# Altium schematic sheet di.SchDoc(di)
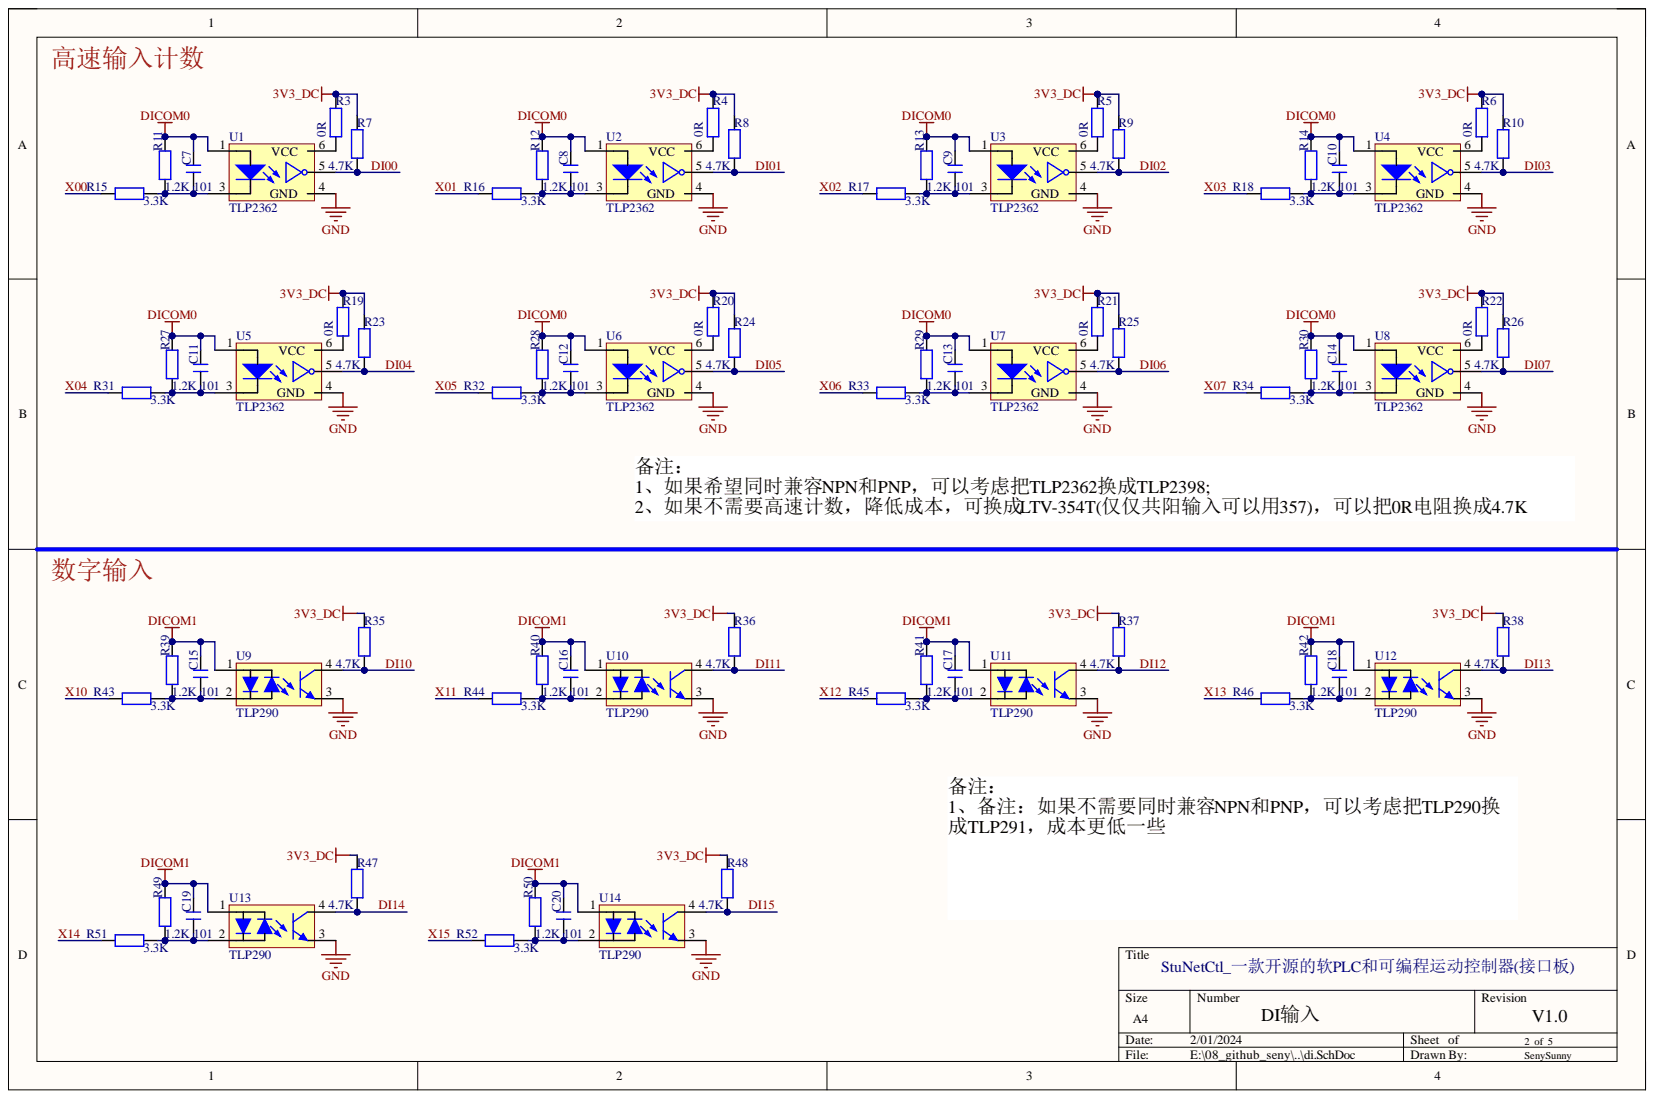
[diagram p0: di.SchDoc]

## Parts
C7  101  [C 0603]
C8  101  [C 0603]
C9  101  [C 0603]
C10  101  [C 0603]
C11  101  [C 0603]
C12  101  [C 0603]
C13  101  [C 0603]
C14  101  [C 0603]
C15  101  [C 0603]
C16  101  [C 0603]
C17  101  [C 0603]
C18  101  [C 0603]
C19  101  [C 0603]
C20  101  [C 0603]
R3  0R  [R 0603]
R4  0R  [R 0603]
R5  0R  [R 0603]
R6  0R  [R 0603]
R7  4.7K  [R 0603]
R8  4.7K  [R 0603]
R9  4.7K  [R 0603]
R10  4.7K  [R 0603]
R11  1.2K  [R 0603]
R12  1.2K  [R 0603]
R13  1.2K  [R 0603]
R14  1.2K  [R 0603]
R15  3.3K  [R 0603]
R16  3.3K  [R 0603]
R17  3.3K  [R 0603]
R18  3.3K  [R 0603]
R19  0R  [R 0603]
R20  0R  [R 0603]
R21  0R  [R 0603]
R22  0R  [R 0603]
R23  4.7K  [R 0603]
R24  4.7K  [R 0603]
R25  4.7K  [R 0603]
R26  4.7K  [R 0603]
R27  1.2K  [R 0603]
R28  1.2K  [R 0603]
R29  1.2K  [R 0603]
R30  1.2K  [R 0603]
R31  3.3K  [R 0603]
R32  3.3K  [R 0603]
R33  3.3K  [R 0603]
R34  3.3K  [R 0603]
R35  4.7K  [R 0603]
R36  4.7K  [R 0603]
R37  4.7K  [R 0603]
R38  4.7K  [R 0603]
R39  1.2K  [R 0603]
R40  1.2K  [R 0603]
R41  1.2K  [R 0603]
R42  1.2K  [R 0603]
R43  3.3K  [R 0603]
R44  3.3K  [R 0603]
R45  3.3K  [R 0603]
R46  3.3K  [R 0603]
R47  4.7K  [R 0603]
R48  4.7K  [R 0603]
R49  1.2K  [R 0603]
R50  1.2K  [R 0603]
R51  3.3K  [R 0603]
R52  3.3K  [R 0603]
U1  TLP2362  [SOP5]
U2  TLP2362  [SOP5]
U3  TLP2362  [SOP5]
U4  TLP2362  [SOP5]
U5  TLP2362  [SOP5]
U6  TLP2362  [SOP5]
U7  TLP2362  [SOP5]
U8  TLP2362  [SOP5]
U9  TLP290  [SOP4_L_P1.27]
U10  TLP290  [SOP4_L_P1.27]
U11  TLP290  [SOP4_L_P1.27]
U12  TLP290  [SOP4_L_P1.27]
U13  TLP290  [SOP4_L_P1.27]
U14  TLP290  [SOP4_L_P1.27]

## Nets
3V3_DC: R3.1 R4.1 R5.1 R6.1 R7.1 R8.1 R9.1 R10.1 R19.1 R20.1 R21.1 R22.1 R23.1 R24.1 R25.1 R26.1 R35.1 R36.1 R37.1 R38.1 R47.1 R48.1
DICOM0: C7.2 C8.2 C9.2 C10.2 C11.2 C12.2 C13.2 C14.2 R11.1 R12.1 R13.1 R14.1 R27.1 R28.1 R29.1 R30.1 U1.1 U2.1 U3.1 U4.1 U5.1 U6.1 U7.1 U8.1
DICOM1: C15.2 C16.2 C17.2 C18.2 C19.2 C20.2 R39.1 R40.1 R41.1 R42.1 R49.1 R50.1 U9.1 U10.1 U11.1 U12.1 U13.1 U14.1
GND: U1.4(GND) U2.4(GND) U3.4(GND) U4.4(GND) U5.4(GND) U6.4(GND) U7.4(GND) U8.4(GND) U9.3 U10.3 U11.3 U12.3 U13.3 U14.3
DI00: R7.2 U1.5
DI01: R8.2 U2.5
DI02: R9.2 U3.5
DI03: R10.2 U4.5
DI04: R23.2 U5.5
DI05: R24.2 U6.5
DI06: R25.2 U7.5
DI07: R26.2 U8.5
DI10: R35.2 U9.4
DI11: R36.2 U10.4
DI12: R37.2 U11.4
DI13: R38.2 U12.4
DI14: R47.2 U13.4
DI15: R48.2 U14.4
X00: R15.2
X01: R16.2
X02: R17.2
X03: R18.2
X04: R31.2
X05: R32.2
X06: R33.2
X07: R34.2
X10: R43.2
X11: R44.2
X12: R45.2
X13: R46.2
X14: R51.2
X15: R52.2
NetC7_1: C7.1 R11.2 R15.1 U1.3
NetC8_1: C8.1 R12.2 R16.1 U2.3
NetC9_1: C9.1 R13.2 R17.1 U3.3
NetC10_1: C10.1 R14.2 R18.1 U4.3
NetC11_1: C11.1 R27.2 R31.1 U5.3
NetC12_1: C12.1 R28.2 R32.1 U6.3
NetC13_1: C13.1 R29.2 R33.1 U7.3
NetC14_1: C14.1 R30.2 R34.1 U8.3
NetC15_1: C15.1 R39.2 R43.1 U9.2
NetC16_1: C16.1 R40.2 R44.1 U10.2
NetC17_1: C17.1 R41.2 R45.1 U11.2
NetC18_1: C18.1 R42.2 R46.1 U12.2
NetC19_1: C19.1 R49.2 R51.1 U13.2
NetC20_1: C20.1 R50.2 R52.1 U14.2
NetR3_2: R3.2 U1.6(VCC)
NetR4_2: R4.2 U2.6(VCC)
NetR5_2: R5.2 U3.6(VCC)
NetR6_2: R6.2 U4.6(VCC)
NetR19_2: R19.2 U5.6(VCC)
NetR20_2: R20.2 U6.6(VCC)
NetR21_2: R21.2 U7.6(VCC)
NetR22_2: R22.2 U8.6(VCC)

## Library data of the parts
C7-C20 = C: 无极性贴片电容; 版权归属: 源创客; BOM名称: 无极性贴片电容; Component Kind: Standard; 学习资源: www.ooocke.com; Library Name: zblib_v1.IntLib
R3-R52 = R: 贴片电阻; 版权归属: 源创客; BOM名称: 贴片电阻; Component Kind: Standard; 学习资源: www.ooocke.com; Library Name: zblib_v1.IntLib
U1-U8 = TLP115: 超高速光耦; Component Kind: Standard; Library Name: zblib_v1.IntLib
U9-U14 = TLP290: 双向光耦，小体积，间距50mil; Component Kind: Standard; Library Name: zblib_v1.IntLib
